# Altium schematic sheet PowerSupply.SchDoc
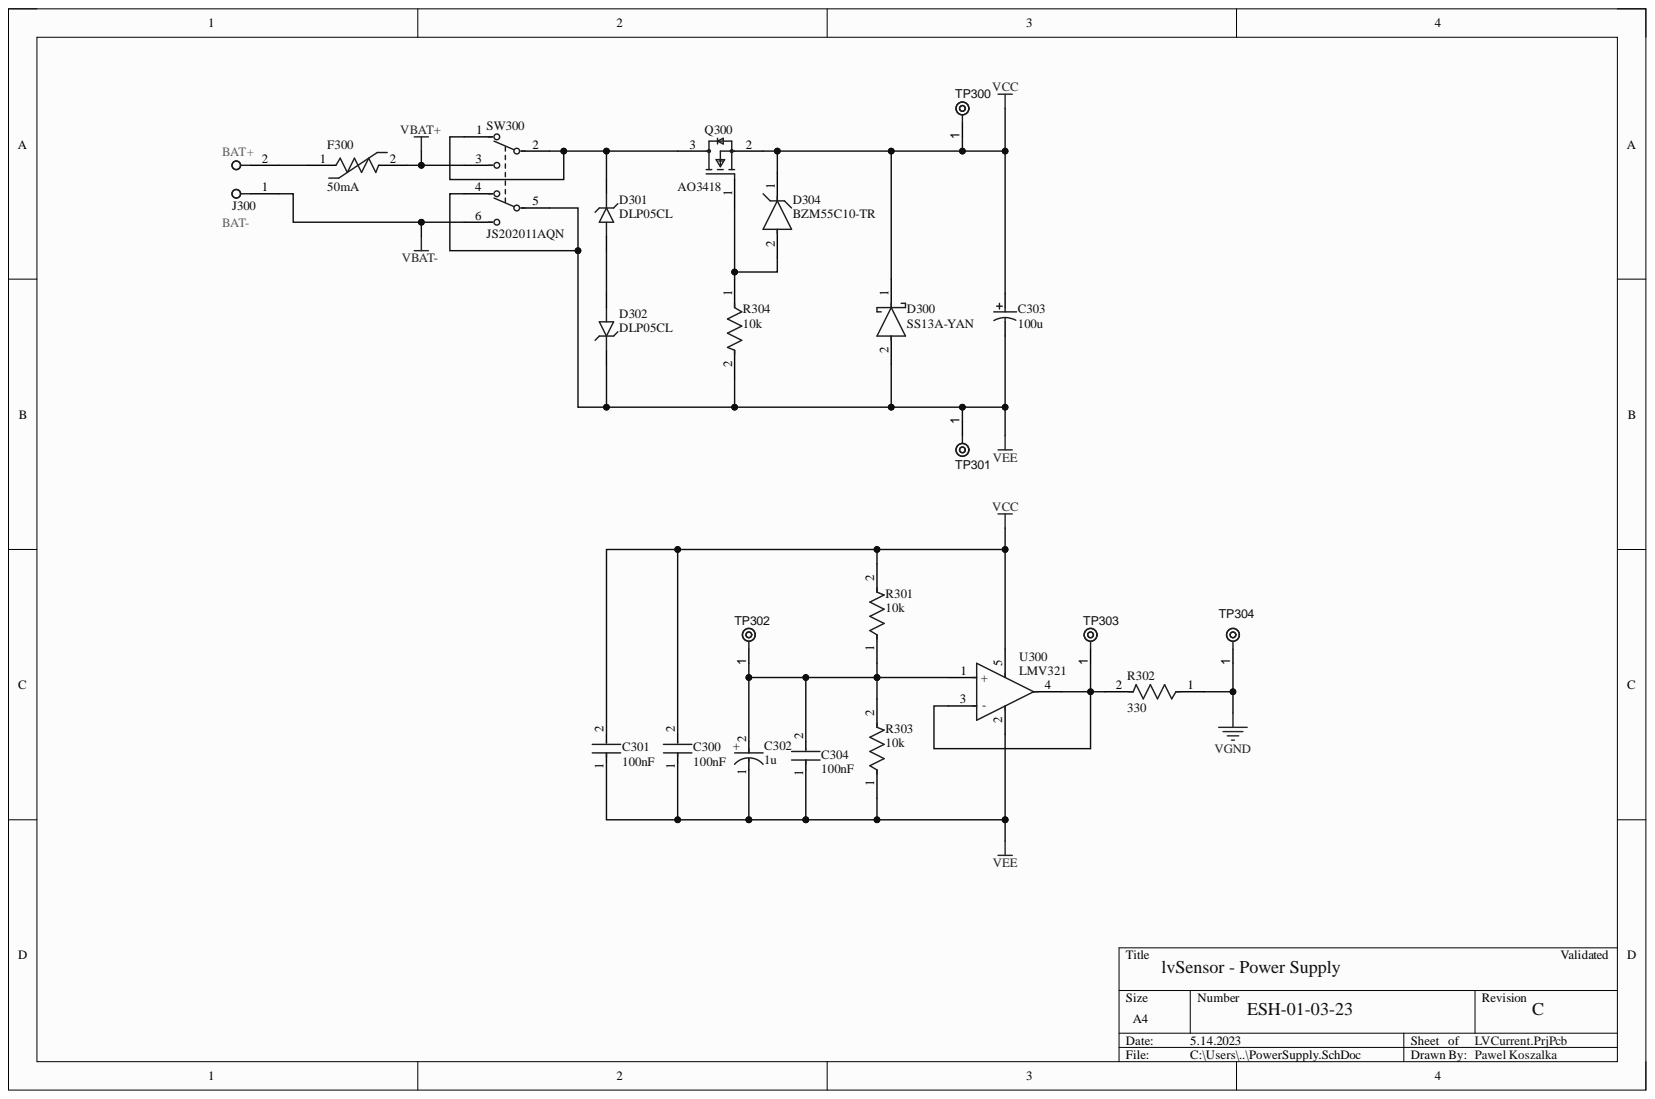
[diagram p0: PowerSupply.SchDoc]

## Parts
C300  100nF  [FP-GRM21B-0_15-IPC_C]
C301  100nF  [FP-GRM21B-0_15-IPC_C]
C302  1u  [FP-SB-MFG]
C303  100u  [PNSC-HA-V-D_V]
C304  100nF  [FP-GRM21B-0_15-IPC_C]
D300  SS13A-YAN  [FP-95400-MFG]
D301  DLP05CL  [DLP05CL]
D302  DLP05CL  [DLP05CL]
D304  BZM55C10-TR  [FP-6_560-5007_01-4-MFG]
F300  50mA  [FP-1210L-MFG]
J300  Wire_solder_2  [WireSolder]  (value not drawn)
Q300  AO3418  [FP-SOT23-MFG]
R301  10k  [FP-RC1206-MFG]
R302  330  [FP-RC1206-MFG]
R303  10k  [FP-RC1206-MFG]
R304  10k  [FP-RC1206-MFG]
SW300  JS202011AQN  [FP-JS202011AQN-MFG]
TP300  TPAD6R  [TP06R]  (value not drawn)  (no BOM)
TP301  TPAD6R  [TP06R]  (value not drawn)  (no BOM)
TP302  TPAD6R  [TP06R]  (value not drawn)  (no BOM)
TP303  TPAD6R  [TP06R]  (value not drawn)  (no BOM)
TP304  TPAD6R  [TP06R]  (value not drawn)  (no BOM)
U300  LMV321  [FP-DBV0005A-IPC_B]

## Nets
VBAT+: F300.2 SW300.3
VBAT-: J300.1 SW300.6
VCC: C300.2 C301.2 C303.1 D300.1 D304.1 Q300.2 R301.2 TP300.1 U300.5
VEE: C300.1 C301.1 C302.1 C303.2 C304.1 D300.2 D302.1 R303.1 R304.2 SW300.4 SW300.5 TP301.1 U300.2
VGND: R302.1 TP304.1
NetC302_2: C302.2 C304.2 R301.1 R303.2 TP302.1 U300.1(+)
NetD301_1: D301.1 Q300.3 SW300.1 SW300.2
NetD301_2: D301.2 D302.2
NetD304_2: D304.2 Q300.1 R304.1
NetF300_1: F300.1 J300.2
NetR302_2: R302.2 TP303.1 U300.3(-) U300.4

## Library data of the parts
C300 C301 C304 = CMP-2007-03541-2: CC0805KPX7R9104  0805 Capacitor; Capacitance: 10uF; Dielectric: X5R; Material: Ceramic; Tolerance: 10%; Voltage: 25V; Case Code (Imperial): 0805; Case Code (Metric): 2012; Case/Package: 0805; Component Kind: Standard; Depth: 1.25mm; Height: 1.25mm; Lead Free: Lead Free; Length: 2mm; Library Name: Altium Content Vault; Max Operating Temperature: 85°C; Min Operating Temperature: -55°C; Mount: Surface Mount; Package Quantity: 1; Packaging: Tape and Reel; Radiation Hardening: No; Termination: SMD/SMT; Thickness: 0.053inch; Voltage Rating: 25V; Voltage Rating (DC): 25V; Width: 0.049inch
C302 = CMP-05427-000070-1: CAP ALUM 1UF 20% 50V SMD; Dielectric Material: Aluminium; Lead Length: 1.8mm; Leakage Current: 3uA; Life (Hours): 2000Hour; Polarity: Polar; Ripple Current: 10mA; Capacitance: 1uF; Case/Package: Radial; Component Kind: Standard; Construction: Cylindrical; Contact Plating: Tin; Diameter: 4mm; Height: 5.4mm; Height - Seated (Max): 0.213inch; Lead Free: Lead Free; Lead Pitch: 1mm; Length: 5.5mm; Library Name: Altium Content Vault; Max Operating Temperature: 85°C; Min Operating Temperature: -40°C; Mount: Surface Mount; Number of Pins: 2; Package Quantity: 2000; Packaging: Tape and Reel; Radiation Hardening: No; REACH SVHC: No SVHC; Ripple Current (AC): 10mA; RoHS Compliant: Yes; Series: S; Termination: SMD/SMT; Tolerance: 20%; Voltage: 50V; Voltage Rating: 50V; Voltage Rating (DC): 50V; Width: 4.3mm
C303 = CMP-2000-06219-2: Aluminum Electrolytic Capacitor, 100 uF, +/- 20%, 16 V, 2-Pin SMD (Size Code D), -40 to 105 degC, RoHS, Tape and Reel; Manufacturer: Panasonic; Capacitance: 100µF; Lifetime@Temperature: 1000Hrs@105°C; Rated Voltage: 16V; Ripple Current: 70mA; Type: Can; Case Size/Dimension: Ø6.3x5.4mm; Component Kind: Standard; Datasheet URL: https://industrial.panasonic.com/cdbs/www-data/pdf/RDE0000/ABA0000C11.pdf; Datasheet Version: 03/2015; Library Name: Altium Content Vault; Manufacturer URL: http://www.panasonic.com/; Mounting Technology: SurfaceMount; Operating Temp Range: -40to+105°C; Operating Temp-Max: 105°C; Package Description: 2-Pin Electrolytic Aluminum Capacitor, Body 6.6 x 6.6 mm; Package Reference: HA-V-D; Package URL: http://industrial.panasonic.com/cdbs/www-data/pdf/RDE0000/DME0000COL48.pdf; Series: HA
D300 = CMP-02539-000001-1: SMA Shocttky; Manufacturer: Vishay; Manufacturer Part Number: VS-10MQ100NTRPBF; Capacitance: 38pF; Forward Current: 1.5A; Max Junction Temp: +150°C; Max Repetitive Reverse Voltage: 100V; Max Surge Forward Current: 30A; Peak Reverse Current: 0.1mA; Standoff Height: 0mm; Value: 38pF; Automotive: No; Category: Diode; Component Kind: Standard; ComponentLink2Description: Datasheet; ComponentLink2URL: http://www.vishay.com/docs/94119/vs-10mq100ntrpbf.pdf; Device Class L1: Discrete Semiconductors; Device Class L2: Diodes; Device Class L3: Schottky Diodes; Digikey Description: DIODE SCHOTTKY 100V 1A SMA; Footprint Url: https://www.vishay.com/docs/95400/sma.pdf; Height: 2.29mm; Lead Free: Yes; Library Name: Altium Content Vault; Mouser Description: DIODE SCHOTTKY 100V 1A SMA; Octopart: https://octopart.com/vs-10mq100ntrpbf-vishay-44340924; Package: 95400; Reverse Voltage: 100V; RoHS: TRUE; Temperature: -55°C to +150°C; Temperature Range High: +150°C; Temperature Range Low: -55°C
D304 = CMP-02541-000004-1: DIODE ZENER 10V 500MW MICROMELF; Manufacturer: Vishay; Automotive Grade: Grade 0; Forward Current: 200mA; Max Junction Temp: +175°C; Max Reverse Leakage Current: 0.1uA; Max Zener Voltage: 10.6V; Reverse Voltage: 7.5V; Zener Impedance: 15Ω; Automotive: Yes; Category: Diode; Component Kind: Standard; ComponentLink2Description: Datasheet; ComponentLink2URL: https://www.vishay.com/docs/85597/bzm55.pdf; Device Class L1: Discrete Semiconductors; Device Class L2: Diodes; Device Class L3: Zener Diodes; Digikey Description: DIODE ZENER 10V 500MW MICROMELF; Height: 1.2mm; Ipc Land Pattern Name: DIOMELF190115; Lead Free: Yes; Library Name: Altium Content Vault; Manufacturer Part Number: BZM55C10-TR; Mouser Description: BZM55 Series 500 mW 10 V Surface Mount Zener Diode - MicroMELF; Nom Zener Voltage: 10V; Octopart: https://octopart.com/bzm55c10-tr-vishay-55507713; Package: 6.560-5007.01-4; Power Dissipation: 500mW; RoHS: TRUE; Temperature: to +175°C; Temperature Range High: +175°C
F300 = CMP-08606-000032-1: SM005-60  1210 PTC fuse; Manufacturer: Littelfuse; Manufacturer Part Number: 1210L020WR; Current Rating: 10A; Hold Current: 0.2A; Resistance: 0.8-5Ω; Trip Current: 0.4A; Trip Time: 0.02s; Value: 0.8-5Ω; Voltage Rating: 30V; Ambient Temperature Range High: +85°C; Ambient Temperature Range Low: -40°C; Automotive: No; Category: Fuse; Component Kind: Standard; ComponentLink2Description: Datasheet; ComponentLink2URL: https://m.littelfuse.com/~/media/electronics/datasheets/resettable_ptcs/littelfuse_ptc_1210l_datasheet.pdf.pdf; Device Class L1: Circuit Protection; Device Class L2: PTC Resettable Fuses; Device Class L3: unset; Digikey Description: PTC RESET FUSE 30V 200MA 1210; Height: 1mm; Lead Free: Yes; Library Name: Altium Content Vault; Mouser Description: PTC Resettable Fuse 0.2A(hold) 0.4A(trip) 30VDC 10A 0.6W 0.02s 0.8Ohm SMD Solder Pad 1210 T/R; Octopart: https://octopart.com/1210l020wr-littelfuse-673644; Package: 1210L; RoHS: TRUE; Temperature: -40°C to +85°C; Temperature Range High: +85°C; Temperature Range Low: -40°C
Q300 = CMP-83955-000005-1: MOSFET N-CH 30V 3.8A SOT23; Gate to Source Voltage (Vgs): 12V; Input Capacitance: 270pF; Max Power Dissipation: 1.4W; Number of Elements: 1; Rds On Max: 60mR; Case/Package: SOT-23; Component Kind: Standard; Continuous Drain Current (ID): 3.8A; Drain to Source Voltage (Vdss): 30V; Lead Free: Lead Free; Library Name: Altium Content Vault; Max Operating Temperature: 150°C; Min Operating Temperature: -55°C; Mount: Surface Mount; Number of Pins: 3; Packaging: Tape and Reel; Power Dissipation: 1.4W; Radiation Hardening: No
R301 R303 = CMP-2100-03681-2: AR1206-10K-0.1%  1206 precission reistor; Manufacturer: YAGEO; Manufacturer Part Number: RC1206JR-070RL; Power Rating: 0.25W; Resistance: 0Ω; Temperature Coefficient: 300ppm/°C; Tolerance: 5%; Value: 0Ω; Voltage Rating: 200V; Automotive: No; Category: Res; Component Kind: Standard; ComponentLink2Description: Datasheet; ComponentLink2URL: https://www.yageo.com/upload/media/product/productsearch/datasheet/rchip/PYu-RC_Group_51_RoHS_L_10.pdf; Composition: Thick Film; Device Class L1: Passive Components; Device Class L2: Resistors; Device Class L3: Chip SMD Resistors; Digikey Description: RES SMD 0 OHM JUMPER 1/4W 1206; Footprint Url: https://www.yageo.com/upload/website/yageo_PYu-R_Mount_10_19050818_343.pdf; Height: 0.65mm; Ipc Land Pattern Name: RESC310160X55; Lead Free: Yes; Library Name: Altium Content Vault; Mouser Description: Resistor: thick film; SMD; 1206; 0O; 0.25W; ±5%; -55÷155°C; Octopart: https://octopart.com/rc1206jr-070rl-yageo-40025601; Package: RC1206; RoHS: TRUE; Series: RC; Temperature: -55°C to +155°C; Temperature Range High: +155°C; Temperature Range Low: -55°C
R302 R304 = CMP-2100-03681-2: WR12X3300FTL  1206 Resistors; Manufacturer: YAGEO; Manufacturer Part Number: RC1206JR-070RL; Power Rating: 0.25W; Resistance: 0Ω; Temperature Coefficient: 300ppm/°C; Tolerance: 5%; Value: 0Ω; Voltage Rating: 200V; Automotive: No; Category: Res; Component Kind: Standard; ComponentLink2Description: Datasheet; ComponentLink2URL: https://www.yageo.com/upload/media/product/productsearch/datasheet/rchip/PYu-RC_Group_51_RoHS_L_10.pdf; Composition: Thick Film; Device Class L1: Passive Components; Device Class L2: Resistors; Device Class L3: Chip SMD Resistors; Digikey Description: RES SMD 0 OHM JUMPER 1/4W 1206; Footprint Url: https://www.yageo.com/upload/website/yageo_PYu-R_Mount_10_19050818_343.pdf; Height: 0.65mm; Ipc Land Pattern Name: RESC310160X55; Lead Free: Yes; Library Name: Altium Content Vault; Mouser Description: Resistor: thick film; SMD; 1206; 0O; 0.25W; ±5%; -55÷155°C; Octopart: https://octopart.com/rc1206jr-070rl-yageo-40025601; Package: RC1206; RoHS: TRUE; Series: RC; Temperature: -55°C to +155°C; Temperature Range High: +155°C; Temperature Range Low: -55°C
SW300 = CMP-10038-000021-1: SWITCH SLIDE DPDT 300MA 6V; Actuator Color: Black; Actuator Length: 2mm; Actuator Material: Nylon; Actuator Type: Standard; Contact Resistance: 70mR; Electrical Life: 5000Cycles; Illumination Type: Non-Illuminated; Switch Function: On-On; Component Kind: Standard; Contact Current Rating: 300mA; Contact Material: Copper Alloy; Contact Plating: Silver; Current Rating: 300mA; Depth: 5.6mm; Housing Material: Nylon; Length: 9mm; Library Name: Altium Content Vault; Max Current Rating: 300mA; Max Operating Temperature: 85°C; Max Voltage Rating (DC): 6V; Min Operating Temperature: -40°C; Mount: Through Hole; Orientation: Right Angle; Package Quantity: 1; Packaging: Bulk; Radiation Hardening: No; REACH SVHC: No SVHC; RoHS Compliant: Yes; Series: JS; Switch Travel: 2mm; Termination: PC Pins; Throw Configuration: DPDT; Voltage Rating (DC): 6V
TP300-TP304 = CMP-00028-00002-2: 0.6mm round; Library Name: LabDevices; Pins: 1
U300 = CMP-04895-000202-1: IC OPAMP GP 1 CIRCUIT SOT23-5; Amplifier Type: GENERAL PURPOSE; Bandwidth: 1MHz; Common Mode Rejection Ratio: 50dB; Input Bias Current: 15nA; Input Offset Voltage (Vos): 1.7mV; Max Supply Voltage: 5.5V; Min Supply Voltage: 2.7V; Nominal Supply Current: 130uA; Number of Amplifiers: 1; Output Current: 60mA; Output Current per Channel: 160mA; Slew Rate: 1V/µs; Voltage Gain: 100dB; Case/Package: SOT-23; Component Kind: Standard; Contact Plating: Tin; Gain Bandwidth Product: 1MHz; Lead Free: Lead Free; Library Name: Altium Content Vault; Max Operating Temperature: 85°C; Min Operating Temperature: -40°C; Mount: Surface Mount; Number of Channels: 1; Number of Circuits: 1; Number of Elements: 1; Number of Pins: 5; Operating Supply Current: 130uA; Package Quantity: 3000; Packaging: Tape and Reel; Power Supply Rejection Ratio (PSRR): 50dB; Radiation Hardening: No; REACH SVHC: No SVHC; RoHS Compliant: Yes; Technology: BICMOS; Unity Gain Bandwidth Product: 1MHz
D301 D302 = DLP05CL: Component Kind: Standard; Library Name: DLP05CL.SchLib
J300 = Wire_solder_2: Component Kind: Standard; Library Name: Connectors_diy.SchLib
